# Altium schematic sheet interconnect.SchDoc
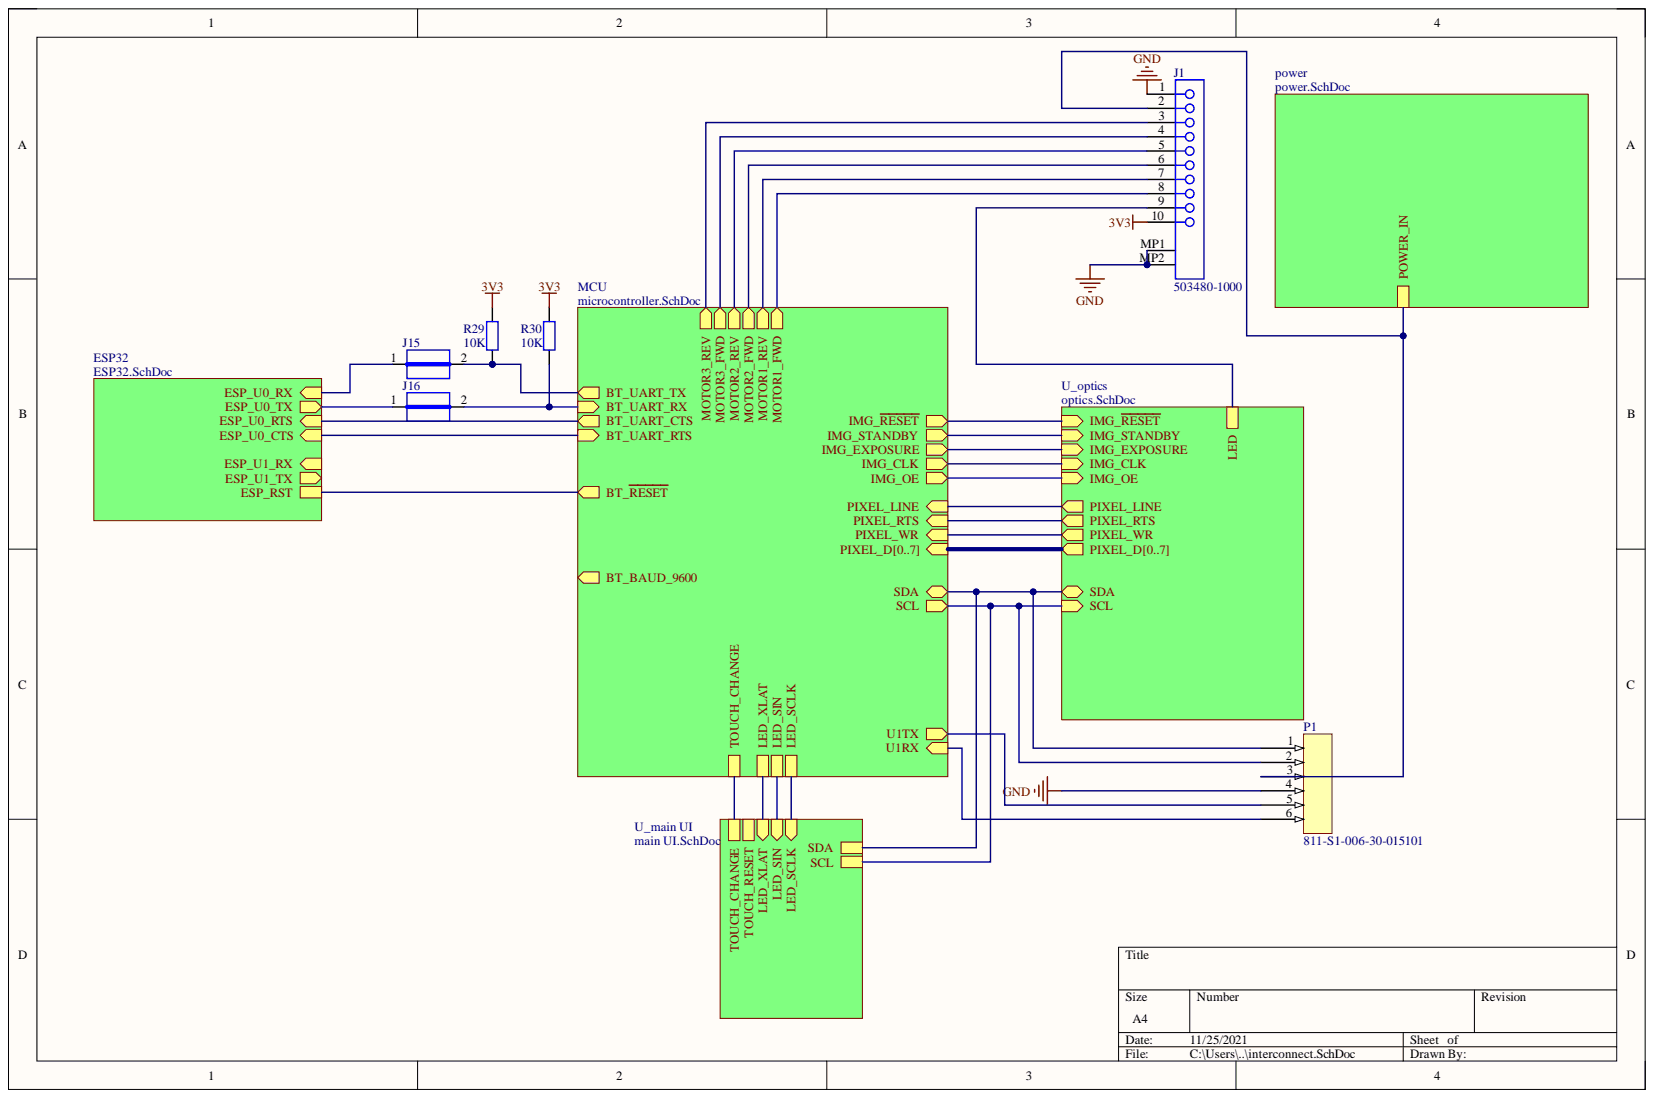
[diagram p0: interconnect.SchDoc]

## Parts
J1  503480-1000  [MOLX-503480-1000]
J15  JUMPER  [JUMPER]  (value not drawn)
J16  JUMPER  [JUMPER]  (value not drawn)
P1  811-S1-006-30-015101  [New footprint 1]
R29  10K  [1608[0603]_M]
R30  10K  [1608[0603]_M]

## Nets
3V3: J1.10 R29.2 R30.2
GND: J1.1 J1.MP1 J1.MP2 P1.4
NetJ1_2: J1.2 P1.3
NetJ1_3: J1.3
NetJ1_4: J1.4
NetJ1_5: J1.5
NetJ1_6: J1.6
NetJ1_7: J1.7
NetJ1_8: J1.8
NetJ1_9: J1.9
NetJ15_1: J15.1
NetJ15_2: J15.2 R29.1
NetJ16_1: J16.1
NetJ16_2: J16.2 R30.1
NetP1_1: P1.1
NetP1_2: P1.2
NetP1_5: P1.5
NetP1_6: P1.6
BT_BAUD_9600:
BT_~{RESET}:
BT_UART_CTS:
BT_UART_RTS:
ESP_U1_RX:
ESP_U1_TX:
IMG_CLK:
IMG_EXPOSURE:
IMG_OE:
IMG_~{RESET}:
IMG_STANDBY:
LED_SCLK:
LED_SIN:
LED_XLAT:
PIXEL_D0:
PIXEL_D1:
PIXEL_D2:
PIXEL_D3:
PIXEL_D4:
PIXEL_D5:
PIXEL_D6:
PIXEL_D7:
PIXEL_LINE:
PIXEL_RTS:
PIXEL_WR:
TOUCH_CHANGE:
TOUCH_RESET:

## Library data of the parts
J1 = CMP-1241-00115-1: 0.5 mm Pitch Easy-On(TM) BackFlip(TM) Type FPC Connector, 1.0 mm Mated Height, Right Angle, SMT, Dual Contact, 10 Circuits, -40 to 85 degC, ELV and RoHS Compliant, Tape and Reel; Manufacturer: Molex; Circuits (Loaded): 10; Current (Max per Contact): 0.5A; Entry Angle: 90degrees Angle; Mated Height: 1.00mm; Material - Metal: Phosphor Bronze; Material - Plating Mating: Gold; Material - Plating Termination: Gold, Tin; PCB Locator: No; PCB Retention: Yes; Pitch - Mating Interface: 0.50mm; Voltage (Max): 50V AC (RMS)/DC; Component Kind: Standard; ComponentLink1Description: Manufacturer URL; ComponentLink1URL: http://www.molex.com/molex/index.jsp; ComponentLink2Description: Datasheet; ComponentLink2URL: http://www.molex.com/webdocs/datasheets/pdf/en-us/5034801000_FFC_FPC_CONNECTORS.pdf; ComponentLink3Description: Package Specification; ComponentLink3URL: http://www.molex.com/pdm_docs/sd/5034801000_sd.pdf; Contact Position: Dual; Durability (mating cycles max): 10; Library Name: Altium Content Vault; Mounting Technology: Surface Mount; Number of Rows: 1; Orientation: Right Angle; PackageDescription: 10-Lead FPC Connector, Pitch 0.5 mm; PackageReference: 503480-1000; PackageVersion: Rev. F, 09/2012; Packing: Tape and Reel; PartNumber: 503480-1000; Polarized to PCB: Yes; RoHS: TRUE; Stackable: No
J15 J16 = CMP-009-00061-1: Component Kind: Standard; Description: JUMPER; Pins: 2; Library Name: EPFL
P1 = CMP-002-00071-6: Conn Spring Piston 6POS SMD; Component Kind: Standard; Library Name: EPFL
R29 R30 = Resistor: Generic Resistor; Component Kind: Standard; Library Name: Passive.SchLib; Value: 10K
